# Altium schematic sheet CPU.SchDoc
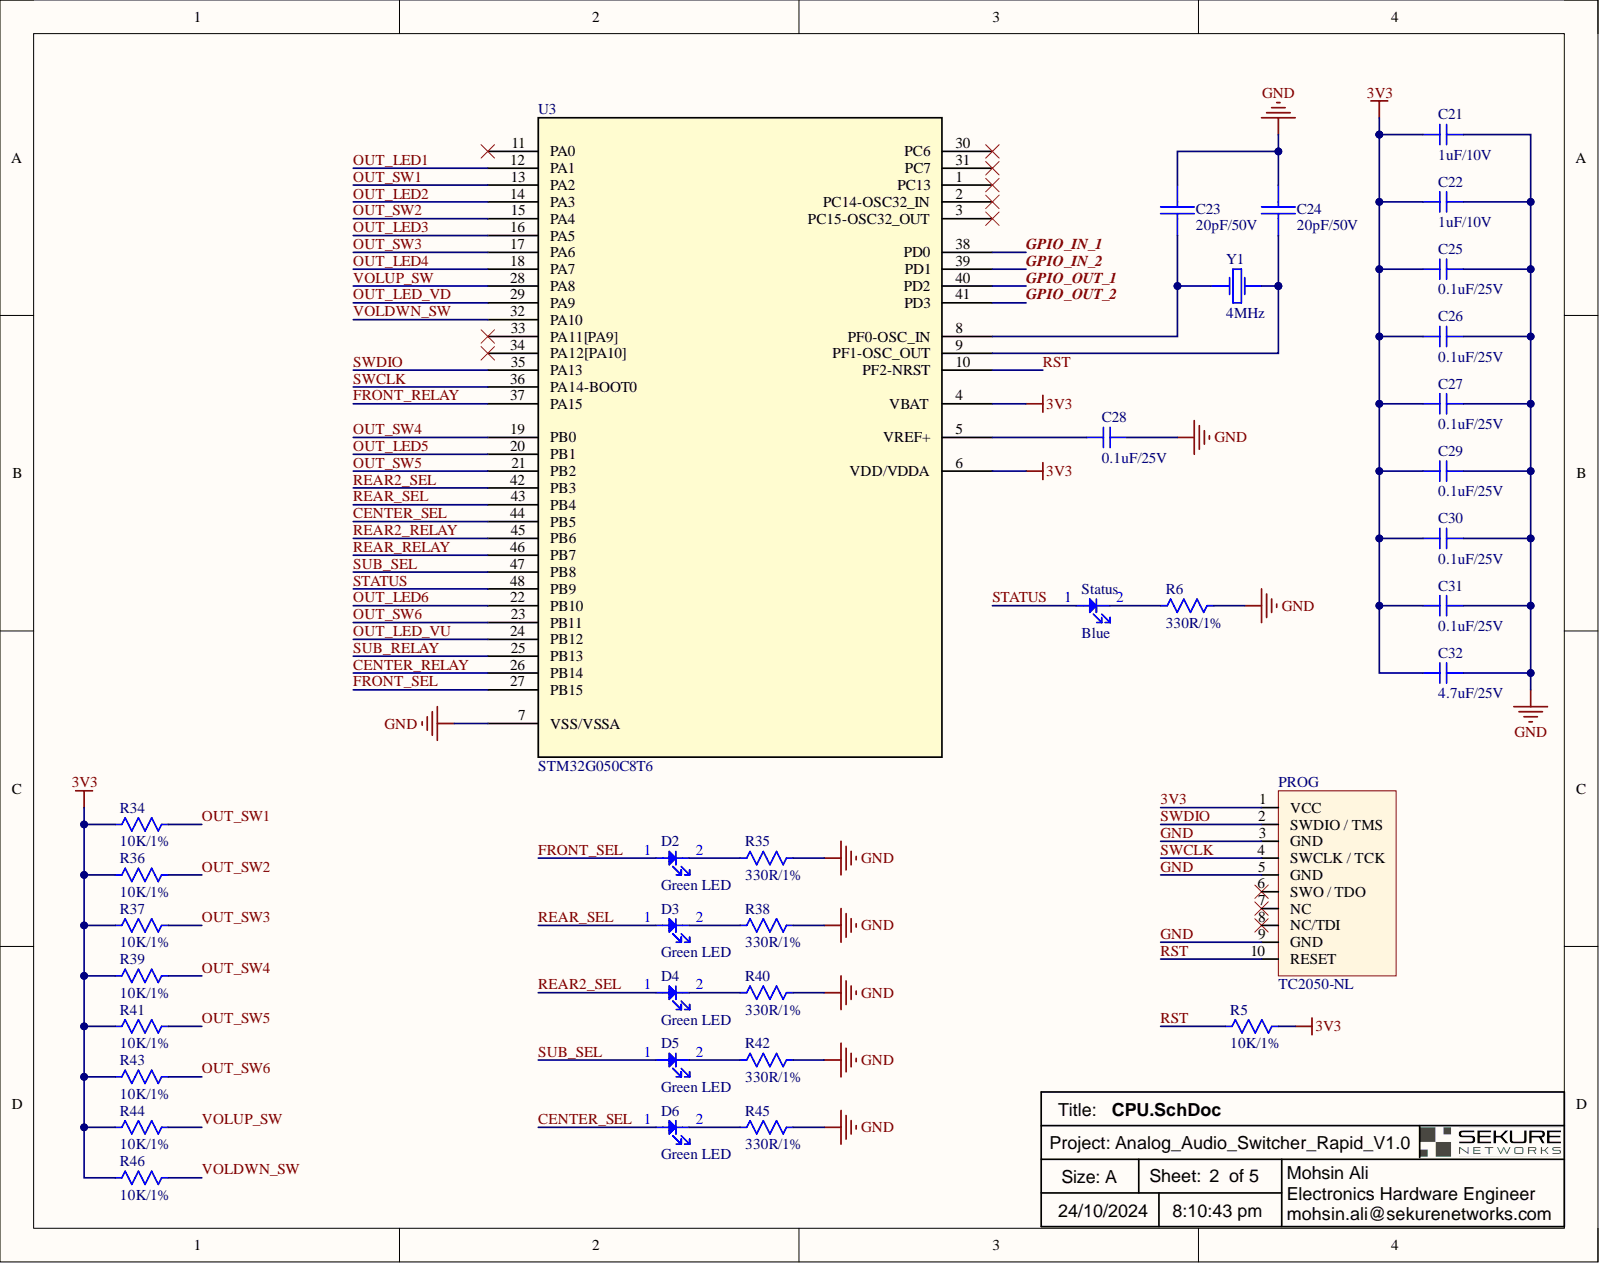
[diagram p0: CPU.SchDoc]

## Parts
C21  value 1uF/10V  [CAP 0603]  (hidden comment: Ceramic Capacitor)
C22  value 1uF/10V  [CAP 0603]  (hidden comment: Ceramic Capacitor)
C23  value 20pF/50V  [CAP 0603]  (hidden comment: Ceramic Capacitor)
C24  value 20pF/50V  [CAP 0603]  (hidden comment: Ceramic Capacitor)
C25  value 0.1uF/25V  [CAP 0603]  (hidden comment: Ceramic Capacitor)
C26  value 0.1uF/25V  [CAP 0603]  (hidden comment: Ceramic Capacitor)
C27  value 0.1uF/25V  [CAP 0603]  (hidden comment: Ceramic Capacitor)
C28  value 0.1uF/25V  [CAP 0603]  (hidden comment: Ceramic Capacitor)
C29  value 0.1uF/25V  [CAP 0603]  (hidden comment: Ceramic Capacitor)
C30  value 0.1uF/25V  [CAP 0603]  (hidden comment: Ceramic Capacitor)
C31  value 0.1uF/25V  [CAP 0603]  (hidden comment: Ceramic Capacitor)
C32  value 4.7uF/25V  [CAP 0603]  (hidden comment: Ceramic Capacitor)
D2  LED  value Green LED  Color: Green  [1206 (3216 Metric)]
D3  LED  value Green LED  Color: Green  [1206 (3216 Metric)]
D4  LED  value Green LED  Color: Green  [1206 (3216 Metric)]
D5  LED  value Green LED  Color: Green  [1206 (3216 Metric)]
D6  LED  value Green LED  Color: Green  [1206 (3216 Metric)]
PROG  value TC2050-NL  [TC2050_full]  (hidden comment: Programming Connector)
R5  value 10K/1%  [RES 0603]  (hidden comment: Resistor)
R6  value 330R/1%  [RES 0603]  (hidden comment: Resistor)
R34  value 10K/1%  [RES 0603]  (hidden comment: Resistor)
R35  value 330R/1%  [RES 0603]  (hidden comment: Resistor)
R36  value 10K/1%  [RES 0603]  (hidden comment: Resistor)
R37  value 10K/1%  [RES 0603]  (hidden comment: Resistor)
R38  value 330R/1%  [RES 0603]  (hidden comment: Resistor)
R39  value 10K/1%  [RES 0603]  (hidden comment: Resistor)
R40  value 330R/1%  [RES 0603]  (hidden comment: Resistor)
R41  value 10K/1%  [RES 0603]  (hidden comment: Resistor)
R42  value 330R/1%  [RES 0603]  (hidden comment: Resistor)
R43  value 10K/1%  [RES 0603]  (hidden comment: Resistor)
R44  value 10K/1%  [RES 0603]  (hidden comment: Resistor)
R45  value 330R/1%  [RES 0603]  (hidden comment: Resistor)
R46  value 10K/1%  [RES 0603]  (hidden comment: Resistor)
Status  value Blue  Color: Blue  [APT1608SURCK]  (hidden comment: LED)
U3  value STM32G050C8T6  [LQFP48]  (hidden comment: Microcontroller)
Y1  value 4MHz  [GC0800044-8MHz]  (hidden comment: Crystal)

## Nets
3V3: C21.1 C22.1 C25.2 C26.2 C27.2 C29.2 C30.2 C31.2 C32.2 PROG.1(VCC) R5.1 R34.2 R36.2 R37.2 R39.2 R41.2 R43.2 R44.2 R46.2 U3.4(VBAT) U3.6(VDD/VDDA)
CENTER_RELAY: U3.26(PB14)
CENTER_SEL: D6.1 U3.44(PB5)
FRONT_RELAY: U3.37(PA15)
FRONT_SEL: D2.1 U3.27(PB15)
GND: C21.2 C22.2 C23.1 C24.1 C25.1 C26.1 C27.1 C28.1 C29.1 C30.1 C31.1 C32.1 PROG.3(GND) PROG.5(GND) PROG.9(GND) R6.1 R35.1 R38.1 R40.1 R42.1 R45.1 U3.7(VSS/VSSA)
GPIO_IN_1: U3.38(PD0)
GPIO_IN_2: U3.39(PD1)
GPIO_OUT_1: U3.40(PD2)
GPIO_OUT_2: U3.41(PD3)
OUT_LED1: U3.12(PA1)
OUT_LED2: U3.14(PA3)
OUT_LED3: U3.16(PA5)
OUT_LED4: U3.18(PA7)
OUT_LED5: U3.20(PB1)
OUT_LED6: U3.22(PB10)
OUT_LED_VD: U3.29(PA9)
OUT_LED_VU: U3.24(PB12)
OUT_SW1: R34.1 U3.13(PA2)
OUT_SW2: R36.1 U3.15(PA4)
OUT_SW3: R37.1 U3.17(PA6)
OUT_SW4: R39.1 U3.19(PB0)
OUT_SW5: R41.1 U3.21(PB2)
OUT_SW6: R43.1 U3.23(PB11)
REAR2_RELAY: U3.45(PB6)
REAR2_SEL: D4.1 U3.42(PB3)
REAR_RELAY: U3.46(PB7)
REAR_SEL: D3.1 U3.43(PB4)
RST: PROG.10(RESET) R5.2 U3.10(PF2-NRST)
STATUS: Status.1 U3.48(PB9)
SUB_RELAY: U3.25(PB13)
SUB_SEL: D5.1 U3.47(PB8)
SWCLK: PROG.4(SWCLK_/_TCK) U3.36(PA14-BOOT0)
SWDIO: PROG.2(SWDIO_/_TMS) U3.35(PA13)
VOLDWN_SW: R46.1 U3.32(PA10)
VOLUP_SW: R44.1 U3.28(PA8)
NetC23_2: C23.2 U3.8(PF0-OSC_IN) Y1.1
NetC24_2: C24.2 U3.9(PF1-OSC_OUT) Y1.2
NetC28_2: C28.2 U3.5(VREF+)
NetD2_2: D2.2 R35.2
NetD3_2: D3.2 R38.2
NetD4_2: D4.2 R40.2
NetD5_2: D5.2 R42.2
NetD6_2: D6.2 R45.2
NetR6_2: R6.2 Status.2

Not connected: PROG 6(SWO_/_TDO) 7(NC) 8(NC/TDI); U3 1(PC13) 2(PC14-OSC32_IN) 3(PC15-OSC32_OUT) 11(PA0) 30(PC6) 31(PC7) 33(PA11[PA9]) 34(PA12[PA10])

## Library data of the parts
C21 C22 = CL10B105KP8NNNC: Multilayer Ceramic Capacitor, 1 uF, 10 V, ± 10%, X7R, 0603 [1608 Metric]; Manufacturer: Samsung; Material: 4241ConductorMaterial; Voltage Rating: 10V; Case Code (Imperial): 0603; Case Code (Metric): 1608; Case/Package: 0603; Category: Ceramic Capacitors; Component Kind: Standard; Construction: Flat; Depth: 0.8mm; Dielectric: X7R; DigiKey: 1276-1946-1-ND; Height: 0.8mm; Lead Free: Lead Free; Length: 1.6mm; Library Name: Ceramic Capacitors.IntLib; Max Operating Temperature: 125°C; Min Operating Temperature: -55°C; Mounting Technology: Surface Mount; P/N: CL10B105KP8NNNC; Package Quantity: 1; Packaging: Tape and Reel; Pricing: 1=0.1, 10=0.026, 50=0.0146, 100=0.0114, 500=0.00858, 1000=0.00674 (USD); Radiation Hardening: No; RoHS: Yes; RoHS Compliant: Yes; Series: CL; Stock: 7012743; Supplier: Digikey; Thickness: 0.035inch; Tolerance: 10%; Voltage Rating (DC): 10V; Width: 0.031inch
C23 C24 = CC0603GRNPO9BN200: CAP CER 20PF 50V NPO 0603; Manufacturer 1: Yageo; Dielectric: NP0; Insulation Resistance: 10GR; Tolerance: 2%; Capacitance: 20pF; Case Code (Imperial): 0603; Case Code (Metric): 1608; Case/Package: 0603; Component Kind: Standard; ComponentLink1Description: https://datasheet.ciiva.com/13264/upy-gp-np0-16v-to-50v-8-3714-13264457.pdf?src-supplier=Mouser; ComponentLink1URL: https://datasheet.ciiva.com/13264/upy-gp-np0-16v-to-50v-8-3714-13264457.pdf?src-supplier=Mouser; DigiKey: 311-3924-1-ND; Lead Free: Lead Free; Length: 0.063inch; Library Name: Ceramic Capacitors.IntLib; Max Operating Temperature: 125°C; Min Operating Temperature: -55°C; Mount: Surface Mount; P/N: CC0603GRNPO9BN200; Packaging: Tape and Reel; Pricing 1: 1=0.14000, 10=0.09900, 50=0.05640, 100=0.04680, 500=0.03280, 1000=0.02715 (USD); Resistor Type: Ceramic; RoHS: Yes; RoHS Compliant: Yes; Series: CC; Stock 1: 53; Supplier 1: Digi-Key; Termination: SMD/SMT; Thickness: 0.035inch; Voltage Rating: 50V; Voltage Rating (DC): 50V; Weight: 7.1E-05oz; Width: 0.031inch
C25-C31 = CL10B104KA8NNNC: Multilayer Ceramic Capacitor, 0.1 uF, 25 V, ± 10%, X7R, 0603 [1608 Metric]; Manufacturer: Samsung; Material: 4241ConductorMaterial; Voltage: 25V; Case Code (Imperial): 0603; Case Code (Metric): 1608; Case/Package: 0603; Category: Ceramic Capacitors; Component Kind: Standard; ComponentLink1Description: https://datasheet.ciiva.com/25805/cl10b104ka8nnnc-25805120.pdf?src-supplier=Digikey; ComponentLink1URL: https://datasheet.ciiva.com/25805/cl10b104ka8nnnc-25805120.pdf?src-supplier=Digikey; ComponentLink2Description: https://datasheet.ciiva.com/26838/getdatasheetpartid-354050-26838409.pdf?src-supplier=Verical; ComponentLink2URL: https://datasheet.ciiva.com/26838/getdatasheetpartid-354050-26838409.pdf?src-supplier=Verical; Depth: 0.8mm; Dielectric: X7R; DigiKey: 1276-1006-1-ND; Height: 0.8mm; Lead Free: Lead Free; Length: 1.6mm; Library Name: Ceramic Capacitors.IntLib; Max Operating Temperature: 125°C; Min Operating Temperature: -55°C; Mounting Technology: Surface Mount; P/N: CL10B104KA8NNNC; Package Quantity: 1; Packaging: Tape and Reel; Pricing: 1=0.1, 10=0.024, 50=0.0136, 100=0.0105, 500=0.00792, 1000=0.00622 (USD); Radiation Hardening: No; RoHS: Yes; RoHS Compliant: Yes; Series: CL; Stock: 708971; Supplier: Digikey; Thickness: 0.035inch; Tolerance: 10%; Voltage Rating: 25V; Voltage Rating (DC): 25V; Width: 0.031inch
C32 = CL10A475MA8NQNC: Ceramic Capacitor, Multilayer, Ceramic, 25V, 20% +Tol, 20% -Tol, X5R, 15% TC, 4.7uF, Surface Mount, 0603; Manufacturer: Samsung; Multilayer: Yes; Capacitor Type: Ceramic; Case/Package: 0603; Category: Ceramic Capacitors; Component Kind: Standard; ComponentLink1Description: https://datasheet.ciiva.com:443/pdfs/VipMasterIC/IC/SEMS/SEMS-S-A0008384515/SEMS-S-A0008873310-1.pdf?src-supplier=IHS+Markit; ComponentLink1URL: https://datasheet.ciiva.com:443/pdfs/VipMasterIC/IC/SEMS/SEMS-S-A0008384515/SEMS-S-A0008873310-1.pdf?src-supplier=IHS+Markit; ComponentLink2Description: https://datasheet.ciiva.com/25787/cl10a475ma8nqnc-25787184.pdf?src-supplier=Digi-Key; ComponentLink2URL: https://datasheet.ciiva.com/25787/cl10a475ma8nqnc-25787184.pdf?src-supplier=Digi-Key; ComponentLink3Description: https://datasheet.ciiva.com/16437/cl10a475ma8nqnc-16437322.pdf?src-supplier=Future+Electronics; ComponentLink3URL: https://datasheet.ciiva.com/16437/cl10a475ma8nqnc-16437322.pdf?src-supplier=Future+Electronics; Dielectric Material: Ceramic; DigiKey: 1276-1903-1-ND; JESD-609 Code: e3; Library Name: Ceramic Capacitors.IntLib; Max Operating Temperature: 85°C; Min Operating Temperature: -55°C; Mounting Technology: Surface Mount; P/N: CL10A475MA8NQNC; Package Shape: Rectangular; Packaging: Tape and Reel; Pins: 2; Pricing: 1=0.27, 10=0.187, 50=0.1244, 100=0.1058, 500=0.07466, 1000=0.06533 (USD); Rated DC Voltage (URdc): 25V; Reach SVHC Compliant: Yes; RoHS: Yes; RoHS Compliant: Yes; Stock: 1889613; Supplier: Digi-Key; Temperature Characteristics Code: X5R; Terminal Finish: Nickel; Termination: Wraparound; Tolerance  (fill in as +-): 20%; Tolerance (fill in as +-): 20%
D2-D6 = LTST-C150KGKT: LED GREEN CLEAR 1206 SMD; Manufacturer: Vishay Lite-On; Dominant Wavelength: 571nm; Forward Current: 30mA; Forward Voltage: 2V; Lens Color: Colorless; Lens Size: 1.6mm; Lens Style: Flat,Diffused,Colorless,Rectangular,Clear; Luminous Intensity: 71mcd; Number of Elements: 1; Power Dissipation: 75mW; Reverse Voltage: 5V; Test Current: 20mA; Viewing Angle: 130°; Wavelength: 574nm; Case Code (Imperial): 1206; Case Code (Metric): 3216; Case/Package: 1206; Category: LEDs; Component Kind: Standard; ComponentLink1Description: https://datasheet.ciiva.com/16200/lite-on20ltst-c150kgkt-16200342.pdf?src-supplier=Future+Electronics; ComponentLink1URL: https://datasheet.ciiva.com/16200/lite-on20ltst-c150kgkt-16200342.pdf?src-supplier=Future+Electronics; ComponentLink2Description: https://datasheet.ciiva.com/25773/ltst-c150kgkt-25773636.pdf?src-supplier=Allied+Electronics; ComponentLink2URL: https://datasheet.ciiva.com/25773/ltst-c150kgkt-25773636.pdf?src-supplier=Allied+Electronics; ComponentLink3Description: https://datasheet.ciiva.com/11775/0900766b80dc0265-11775638.pdf?src-supplier=Rs; ComponentLink3URL: https://datasheet.ciiva.com/11775/0900766b80dc0265-11775638.pdf?src-supplier=Rs; ComponentLink4Description: https://datasheet.ciiva.com/2110/s-110-ltst-c150kgkt-2110161.pdf?src-supplier=Digikey; ComponentLink4URL: https://datasheet.ciiva.com/2110/s-110-ltst-c150kgkt-2110161.pdf?src-supplier=Digikey; ComponentLink5Description: https://datasheet.ciiva.com/2110/s-110-ltst-c150kgkt-2110160.pdf?src-supplier=Digikey; ComponentLink5URL: https://datasheet.ciiva.com/2110/s-110-ltst-c150kgkt-2110160.pdf?src-supplier=Digikey; Depth: 1.6mm; DigiKey: 160-1404-1-ND; Height: 1.1mm; Illumination Color: Green; Lead Free: Lead Free; Length: 3.2mm; Lens Transparency: Clear; Library Name: LEDs.IntLib; Max Operating Temperature: 85°C; Min Operating Temperature: -55°C; Mounting Technology: Surface Mount; Number of LEDs: 1; P/N: LTST-C150KGKT; Package Quantity: 3000; Packaging: Tape and Reel; Pins: 2; Power Rating: 75mW; Pricing: 1=0.27, 10=0.149, 100=0.0901, 500=0.06734, 1000=0.06038 (USD); Radiation Hardening: No; RoHS: Yes; RoHS Compliant: Yes; Series: -; Stock: 649305; Supplier: Digikey; Width: 1.6mm
PROG = TC2050_Full: “No Legs” 10-pin Plug-of-Nails™ Cable fitted with a 10-pin 0.1″ pitch ribbon connector.; Assembly: DNP; Component Kind: Standard; Library Name: Programming Connectors.IntLib
R5 R34 R36 R37 R39 R41 R43 R44 R46 = RC0603FR-0710KL: RES SMD 10K OHM 1% 1/10W 0603; Manufacturer: Yageo; Power Rating: 100mW; Resistance: 10kR; Temperature Coefficient: 100ppm/°C; Voltage Rating: 75V; Voltage Rating (DC): 50V; Case Code (Imperial): 0603; Case Code (Metric): 1608; Case/Package: 0603; Component Kind: Standard; ComponentLink1Description: https://datasheet.ciiva.com/7660/38590-7660789.pdf?src-supplier=Element14; ComponentLink1URL: https://datasheet.ciiva.com/7660/38590-7660789.pdf?src-supplier=Element14; ComponentLink2Description: https://datasheet.ciiva.com/15189/getdatasheetpartid-409289-15189591.pdf?src-supplier=Verical; ComponentLink2URL: https://datasheet.ciiva.com/15189/getdatasheetpartid-409289-15189591.pdf?src-supplier=Verical; ComponentLink3Description: https://datasheet.ciiva.com/46440/1868787-46440891.pdf?src-supplier=Element14; ComponentLink3URL: https://datasheet.ciiva.com/46440/1868787-46440891.pdf?src-supplier=Element14; ComponentLink4Description: https://datasheet.ciiva.com/7983/443971-7983596.pdf?src-supplier=Element14; ComponentLink4URL: https://datasheet.ciiva.com/7983/443971-7983596.pdf?src-supplier=Element14; ComponentLink5Description: https://datasheet.ciiva.com/7746/1738695-7746239.pdf?src-supplier=Element14; ComponentLink5URL: https://datasheet.ciiva.com/7746/1738695-7746239.pdf?src-supplier=Element14; ComponentLink6Description: https://datasheet.ciiva.com/22747/443971-22747519.pdf?src-supplier=Element14; ComponentLink6URL: https://datasheet.ciiva.com/22747/443971-22747519.pdf?src-supplier=Element14; Composition: Thick Film; Depth: 0.8mm; DigiKey: 311-10.0KHRDKR-ND; Height: 0.45mm; Lead Free: Lead Free; Lead Pitch: 0.3mm; Length: 1.6mm; Library Name: Resistors.IntLib; Max Operating Temperature: 155°C; Military Standard: Not; Min Operating Temperature: -55°C; Number of Pins: 2; P/N: RC0603FR-0710KL; Packaging: Tape and Reel; Pricing 1: 1=0.10000, 10=0.02300, 100=0.00940, 1000=0.00421, 2500=0.00366 (USD); REACH SVHC: No SVHC; RoHS: Yes; Stock 1: 55833360; Supplier 1: Digi-Key; Termination: SMD/SMT; Tolerance: 1%; Weight: 7.1E-05oz; Width: 0.8mm
R6 R35 R38 R40 R42 R45 = ERJ3EKF3300V: RES SMD 330 OHM 1% 1/10W 0603; Manufacturer: Panasonic; Max Power Dissipation: 100mW; Resistance: 330R; Temperature Coefficient: 100ppm/°C; Voltage Rating: 75V; Case Code (Imperial): 0603; Case Code (Metric): 1608; Case/Package: 0603; Component Kind: Standard; ComponentLink1Description: https://datasheet.ciiva.com/48531/aoa0000ce2-48531001.pdf?src-supplier=Avnet; ComponentLink1URL: https://datasheet.ciiva.com/48531/aoa0000ce2-48531001.pdf?src-supplier=Avnet; ComponentLink2Description: https://datasheet.ciiva.com/22721/426256-22721099.pdf?src-supplier=Element14; ComponentLink2URL: https://datasheet.ciiva.com/22721/426256-22721099.pdf?src-supplier=Element14; ComponentLink3Description: https://datasheet.ciiva.com/46373/1678782-46373899.pdf?src-supplier=Element14; ComponentLink3URL: https://datasheet.ciiva.com/46373/1678782-46373899.pdf?src-supplier=Element14; ComponentLink4Description: https://datasheet.ciiva.com/7886/aoa0000ce2-7886729.pdf?src-supplier=Element14; ComponentLink4URL: https://datasheet.ciiva.com/7886/aoa0000ce2-7886729.pdf?src-supplier=Element14; ComponentLink5Description: https://datasheet.ciiva.com/9117/aoa0000ce2-9117132.pdf?src-supplier=Avnet; ComponentLink5URL: https://datasheet.ciiva.com/9117/aoa0000ce2-9117132.pdf?src-supplier=Avnet; ComponentLink6Description: https://datasheet.ciiva.com/46373/1678782-46373900.pdf?src-supplier=Element14; ComponentLink6URL: https://datasheet.ciiva.com/46373/1678782-46373900.pdf?src-supplier=Element14; Composition: Thick Film; Contact Plating: Tin; Depth: 0.8mm; DigiKey: P330HCT-ND; Features: Automotive AEC-Q200; Height: 0.45mm; Lead Free: Lead Free; Length: 1.6mm; Library Name: Resistors.IntLib; Max Operating Temperature: 155°C; Military Standard: Not; Min Operating Temperature: -55°C; Mount: Surface Mount; Number of Pins: 2; Number of Terminations: 2; P/N: ERJ3EKF3300V; Package Quantity: 5000; Packaging: Tape and Reel; Power Rating: 100mW; Pricing 1: 1=0.10000, 10=0.07000, 100=0.02840, 1000=0.01275, 2500=0.01106 (USD); Radiation Hardening: No; REACH SVHC: No SVHC; RoHS: Yes; Stock 1: 1950276; Supplier 1: Digi-Key; Termination: SMD/SMT; Tolerance: 1%; Voltage Rating (DC): 75V; Weight: 7.1E-05oz; Width: 0.8mm
Status = APT1608QBC/D: Single Color LED, Blue, Water Clear, 1.2mm; Manufacturer: Kingbright; Channels: 1; Forward Current: 30mA; Luminous Intensity-Nom: 100mcd; Overall Height: 0.75mm; Shape: Rectangular; Viewing Angle: 120°; Category: LEDs; Component Kind: Standard; ComponentLink1Description: https://datasheet.ciiva.com:443/pdfs/VipMasterIC/IC/KNGB/KNGBS06436/KNGBS06436-1.pdf?src-supplier=IHS+Markit; ComponentLink1URL: https://datasheet.ciiva.com:443/pdfs/VipMasterIC/IC/KNGB/KNGBS06436/KNGBS06436-1.pdf?src-supplier=IHS+Markit; ComponentLink2Description: https://datasheet.ciiva.com/12403/apt1608qbc-d-65281-12403861.pdf?src-supplier=Mouser; ComponentLink2URL: https://datasheet.ciiva.com/12403/apt1608qbc-d-65281-12403861.pdf?src-supplier=Mouser; ComponentLink3Description: https://datasheet.ciiva.com/2121/apt1608qbc-d-2121092.pdf?src-supplier=Digikey; ComponentLink3URL: https://datasheet.ciiva.com/2121/apt1608qbc-d-2121092.pdf?src-supplier=Digikey; ComponentLink4Description: https://datasheet.ciiva.com/2121/apt1608qbc-d-2121093.pdf?src-supplier=Digikey; ComponentLink4URL: https://datasheet.ciiva.com/2121/apt1608qbc-d-2121093.pdf?src-supplier=Digikey; ComponentLink5Description: https://datasheet.ciiva.com/2121/apt1608qbc-d-2121094.pdf?src-supplier=Digikey; ComponentLink5URL: https://datasheet.ciiva.com/2121/apt1608qbc-d-2121094.pdf?src-supplier=Digikey; DigiKey: 754-1434-1-ND; Lens Style: WATER CLEAR; Library Name: LEDs.IntLib; Max Operating Temperature: 85°C; Min Operating Temperature: -40°C; Mounting Technology: Surface Mount; Optoelectronic Device Type: SINGLE COLOR LED; P/N: APT1608QBC/D; Packaging: Tape and Reel; Pins: 2; Pricing: 1=0.43, 10=0.263, 100=0.151, 1000=0.11817 (USD); RoHS: Yes; RoHS Compliant: Yes; Size: 1.2mm; Stock: 112434; Supplier: Digikey; Terminal Pitch: 1.65mm
U3 = STM32G050C8T6: ARM® Cortex®-M0+ STM32G0 Microcontroller IC 32-Bit Single-Core 64MHz 64KB (64K x 8) FLASH 48-LQFP (7x7); Manufacturer: STMicroelectronics; Category: Microcontrollers; Component Kind: Standard; DigiKey: 497-STM32G050C8T6-ND; Library Name: Microcontrollers.SchLib; P/N: STM32G050C8T6; Pricing: 1=2.5, 10=2.246, 25=2.1188, 80=1.80538, 250=1.6952, 500=1.48328, 1000=1.22901, 2500=1.14425, 5000=1.10187 (USD); RoHS: Yes; Stock: 2368; Supplier: Digikey
Y1 = ABLS-4.000MHZ-20-B-3-H-T: Parallel - Fundamental Quartz Crystal, 4MHz Nom; Manufacturer: Abracon; Category: Crystals; Component Kind: Standard; ComponentLink1Description: https://datasheet.ciiva.com:443/pdfs/VipMasterIC/IC/ABRC/ABRCS03441/ABRCS03441-1.pdf?src-supplier=IHS+Markit; ComponentLink1URL: https://datasheet.ciiva.com:443/pdfs/VipMasterIC/IC/ABRC/ABRCS03441/ABRCS03441-1.pdf?src-supplier=IHS+Markit; ComponentLink2Description: https://abracon.com/Resonators/abls.pdf?src-supplier=Digikey; ComponentLink2URL: https://abracon.com/Resonators/abls.pdf?src-supplier=Digikey; DigiKey: 535-14919-2-ND; Library Name: Crystals.IntLib; P/N: ABLS-4.000MHZ-20-B-3-H-T; Pricing: 1000=0.17152 (USD); RoHS: Yes; Supplier: Digikey
